# Altium schematic sheet Power.SchDoc
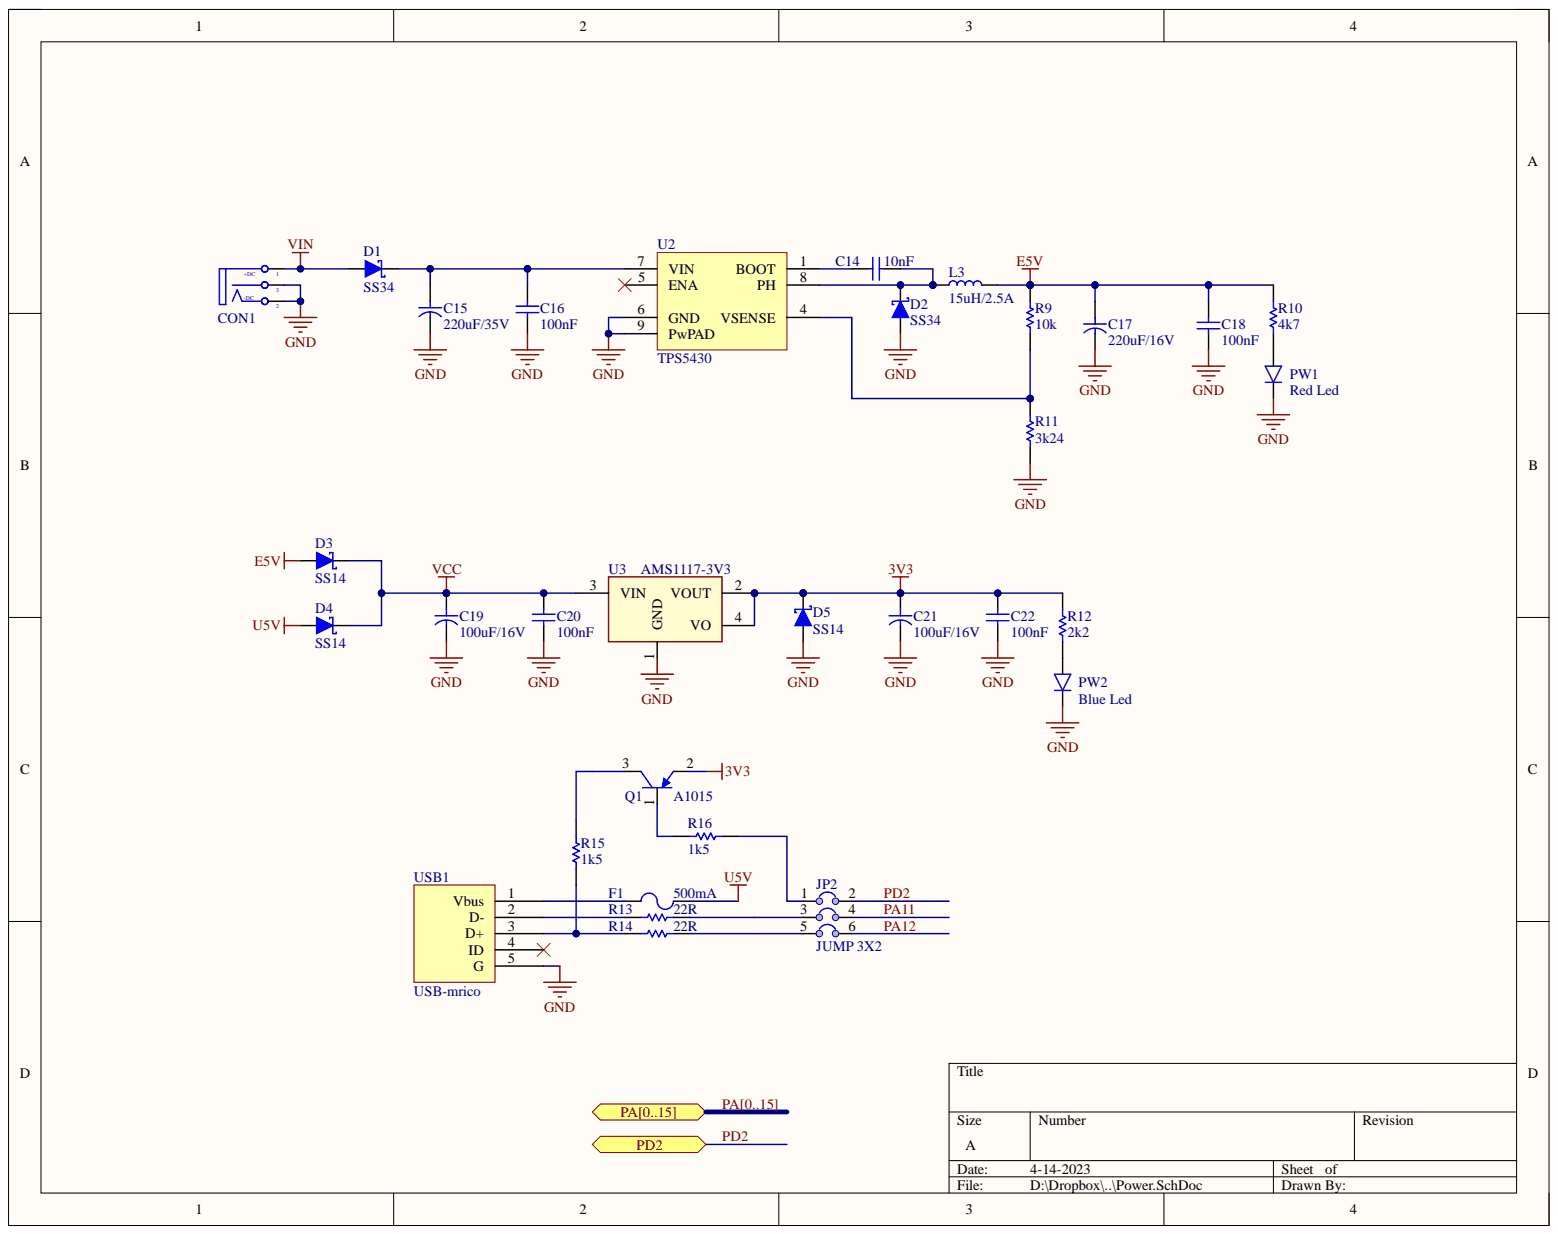
[diagram p0: Power.SchDoc]

## Parts
C14  10nF  [C 0603 (1608)]
C15  220uF/35V  [C SMD ALU (F)]
C16  100nF  [C 0603 (1608)]
C17  220uF/16V  [C SMD ALU (D)]
C18  100nF  [C 0603 (1608)]
C19  100uF/16V  [C SMD ALU (D)]
C20  100nF  [C 0603 (1608)]
C21  100uF/16V  [C SMD ALU (D)]
C22  100nF  [C 0603 (1608)]
CON1  CON DC PWR  [CON DC-044]  (value not drawn)
D1  SS34  [DO-214AC (SMA)]
D2  SS34  [DO-214AC (SMA)]
D3  SS14  [DO-214AC (SMA)]
D4  SS14  [DO-214AC (SMA)]
D5  SS14  [DO-214AC (SMA)]
F1  500mA  [Fuse 0805 SMD]
JP2  JUMP 3X2  [JUMPER 3x2]
L3  15uH/2.5A  [Inductor SMD 0520]
PW1  Red Led  [Led SMD 0603]
PW2  Blue Led  [Led SMD 0603]
Q1  A1015  [SOT-23]
R9  10k  [R 0603 (1608)]
R10  4k7  [R 0603 (1608)]
R11  3k24  [R 0603 (1608)]
R12  2k2  [R 0603 (1608)]
R13  22R  [R 0603 (1608)]
R14  22R  [R 0603 (1608)]
R15  1k5  [R 0603 (1608)]
R16  1k5  [R 0603 (1608)]
U2  TPS5430  [SOIC-8 EP]
U3  AMS1117-3V3  [SOT-223]
USB1  USB-mrico  [CONN/USB/MICRO/RA/H]

## Ports
PA0, PA1, PA2, PA3, PA4, PA5, PA6, PA7, PA8, PA9, PA10, PA11, PA12, PA13, PA14, PA15, PA[0..15], PD2

## Nets
3V3: C21.1 C22.1 D5.2 Q1.2 R12.1 U3.2(VOUT) U3.4(VO)
E5V: C17.1 C18.1 D3.1 L3.2 R9.1 R10.2
GND: C15.2 C16.2 C17.2 C18.2 C19.2 C20.2 C21.2 C22.2 CON1.2 CON1.3 D2.1 D5.1 PW1.2 PW2.2 R11.2 U2.6(GND) U2.9(PwPAD) U3.1(GND) USB1.5(G)
U5V: D4.1 F1.2
VCC: C19.1 C20.1 D3.2 D4.2 U3.3(VIN)
VIN: CON1.1 D1.1
PA11: JP2.4 ; port PA[0..15]  [also labelled PA]
PA12: JP2.6 ; port PA[0..15]  [also labelled PA]
PD2: JP2.2 ; port PD2
NetC14_1: C14.1 U2.1(BOOT)
NetC14_2: C14.2 D2.2 L3.1 U2.8(PH)
NetC15_1: C15.1 C16.1 D1.2 U2.7(VIN)
NetF1_1: F1.1 USB1.1(Vbus)
NetJP2_1: JP2.1 R16.2
NetJP2_3: JP2.3 R13.2
NetJP2_5: JP2.5 R14.2
NetPW1_1: PW1.1 R10.1
NetPW2_1: PW2.1 R12.2
NetQ1_1: Q1.1 R16.1
NetQ1_3: Q1.3 R15.2
NetR9_2: R9.2 R11.1 U2.4(VSENSE)
NetR13_1: R13.1 USB1.2(D-)
NetR14_1: R14.1 R15.1 USB1.3(D+)
PA0: ; port PA[0..15]  [also labelled PA]
PA1: ; port PA[0..15]  [also labelled PA]
PA2: ; port PA[0..15]  [also labelled PA]
PA3: ; port PA[0..15]  [also labelled PA]
PA4: ; port PA[0..15]  [also labelled PA]
PA5: ; port PA[0..15]  [also labelled PA]
PA6: ; port PA[0..15]  [also labelled PA]
PA7: ; port PA[0..15]  [also labelled PA]
PA8: ; port PA[0..15]  [also labelled PA]
PA9: ; port PA[0..15]  [also labelled PA]
PA10: ; port PA[0..15]  [also labelled PA]
PA13: ; port PA[0..15]  [also labelled PA]
PA14: ; port PA[0..15]  [also labelled PA]
PA15: ; port PA[0..15]  [also labelled PA]

Not connected: U2 5(ENA); USB1 4(ID)

## Library data of the parts
C14 C16 C18 C20 C22 = Capacitor NP: Capacitor non-pol; Component Kind: Standard; Library Name: BKIT4U_LIB.SchLib
C15 C17 C19 C21 = Capacitor: Capacitor pol; Component Kind: Standard; Library Name: BKIT4U_LIB.SchLib
CON1 = CON DC PWR: DC input connector; Component Kind: Standard; Library Name: BKIT4U_LIB.SchLib
D1-D5 = Diode Schottky: Schottky Diode; Code_JEDEC: DO-214-AA; Component Kind: Standard; LatestRevisionDate: 17-Jul-2002; LatestRevisionNote: Re-released for DXP Platform.; Library Name: BKIT4U_LIB.SchLib; PackageReference: SMB; Published: 8-Jun-2000; Publisher: Altium Limited
F1 = Fuse: Component Kind: Standard; Description: Fuse; Library Name: BKIT4U_LIB.SchLib
L3 = Inductor: Component Kind: Standard; Description: Inductor; Library Name: BKIT4U_LIB.SchLib
PW1 = Led: Typical LED; Component Kind: Standard; Library Name: BKIT4U_LIB.SchLib
PW2 = Led: Component Kind: Standard; Description: Blue Led; Library Name: BKIT4U_LIB.SchLib
Q1 = Transistor PNP: PNP Bipolar Transistor; Component Kind: Standard; LatestRevisionDate: 29-May-2009; LatestRevisionNote: IPC-7351 Footprint Added.; Library Name: BKIT4U_LIB.SchLib; PackageDescription: 3-Pin SOT-23 Package 0.95 mm Pitch, 2.4 mm Lead Span; PackageReference: SOT-23B; PackageVersion: Aug-1999; Published: 8-Jun-2000; Publisher: Altium Limited
R9-R16 = Resistor: Component Kind: Standard; Description: Resistor; LatestRevisionDate: 17-Jul-2002; LatestRevisionNote: Re-released for DXP Platform.; Library Name: BKIT4U_LIB.SchLib; PackageReference: AXIAL-0.3; Published: 8-Jun-2000; Publisher: Altium Limited
U2 = TPS54xx: 3/5A, Wide input range, Step-down SWIFT DC-DC Converter; Component Kind: Standard; Library Name: BKIT4U_LIB.SchLib
U3 = AMS1117: 1A LDO Voltage Regulator, ADJ or fixed 1.5/1.8/2.5/2.85/3.3/5V; Component Kind: Standard; Library Name: BKIT4U_LIB.SchLib
USB1 = usb-STM: Component Kind: Standard; Library Name: STM32F103C8T6 Bluepill.SchLib
JP2 = JUMP 3X2: Component Kind: Standard; LatestRevisionDate: 17-Jul-2002; LatestRevisionNote: Re-released for DXP Platform.; Library Name: BKIT4U_LIB.SchLib; Publisher: Altium Limited
